# Altium schematic sheet 00_main_with_mcu.SchDoc(00_main_with_mcu)
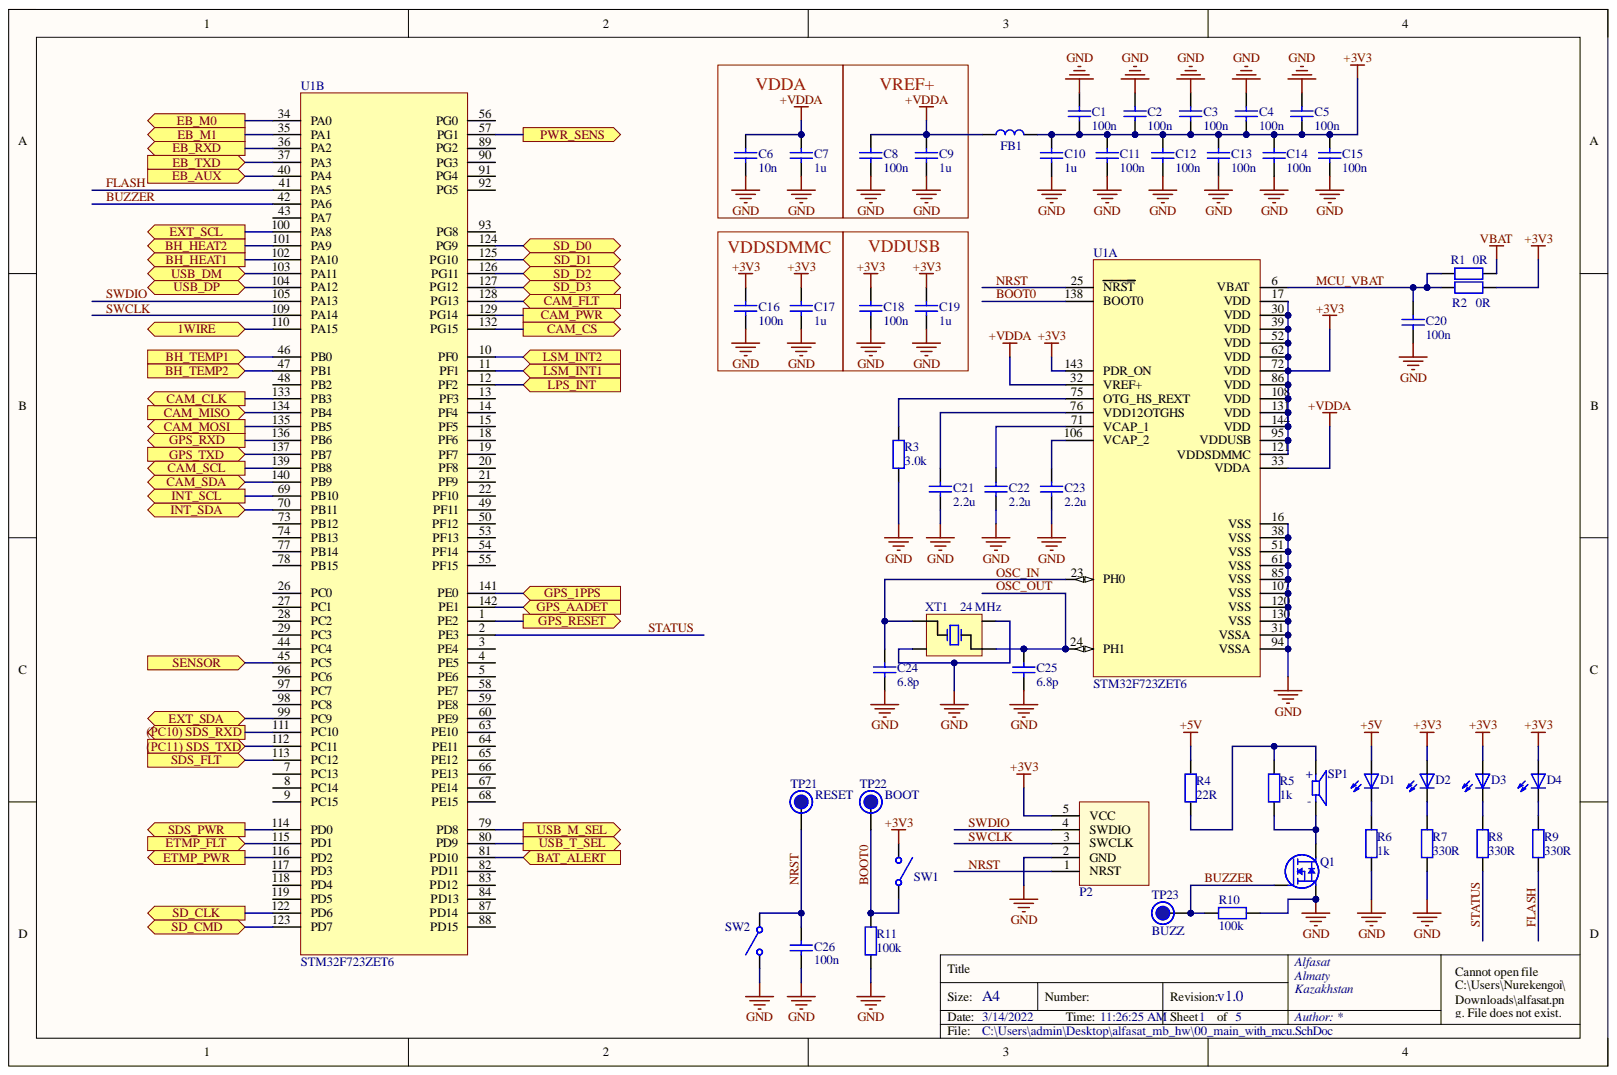
[diagram p0: 00_main_with_mcu.SchDoc]

## Parts
C1  100n  [C0603_IPC_MD]
C2  100n  [C0603_IPC_MD]
C3  100n  [C0603_IPC_MD]
C4  100n  [C0603_IPC_MD]
C5  100n  [C0603_IPC_MD]
C6  10n  [C0603_IPC_MD]
C7  1u  [C0603_IPC_MD]
C8  100n  [C0603_IPC_MD]
C9  1u  [C0603_IPC_MD]
C10  1u  [C0603_IPC_MD]
C11  100n  [C0603_IPC_MD]
C12  100n  [C0603_IPC_MD]
C13  100n  [C0603_IPC_MD]
C14  100n  [C0603_IPC_MD]
C15  100n  [C0603_IPC_HD]
C16  100n  [C0603_IPC_MD]
C17  1u  [C0603_IPC_MD]
C18  100n  [C0603_IPC_HD]
C19  1u  [C0603_IPC_HD]
C20  100n  [C0603_IPC_MD]
C21  2.2u  [C0603_IPC_MD]
C22  2.2u  [C0603_IPC_MD]
C23  2.2u  [C0603_IPC_MD]
C24  6.8p  [C0603_IPC_MD]
C25  6.8p  [C0603_IPC_MD]
C26  100n  [C0603_IPC_MD]
D1  LTST-C190GKT  [LED_0603]  (value not drawn)
D2  LTST-C190GKT  [LED_0603]  (value not drawn)
D3  LTST-C190GKT  [LED_0603]  (value not drawn)
D4  LTST-C190GKT  [LED_0603]  (value not drawn)
FB1  MH1608-601Y  [L0603_IPC_MD]  (value not drawn)
P2  SWD  [SWD_CON_VAR2]  (no BOM)
Q1  BSS816NW  [SOT323]  (value not drawn)
R1  0R  [R0603_IPC_MD]
R2  0R  [R0603_IPC_MD]
R3  3.0k  [R0603_IPC_MD]
R4  22R  [R0603_IPC_MD]
R5  1k  [R0603_IPC_MD]
R6  1k  [R0603_IPC_MD]
R7  330R  [R0603_IPC_MD]
R8  330R  [R0603_IPC_MD]
R9  330R  [R0603_IPC_MD]
R10  100k  [R0603_IPC_MD]
R11  100k  [R0603_IPC_MD]
SP1  MLT-7525  [SPKR_MLT-7525]  (value not drawn)
SW1  TSA008A18026B  [Switch_tactile_3mm*3.5mm]  (value not drawn)
SW2  TSA008A18026B  [Switch_tactile_3mm*3.5mm]  (value not drawn)
TP21  RESET  [TestPoint_1M0]  (no BOM)
TP22  BOOT  [TestPoint_1M0]  (no BOM)
TP23  BUZZ  [TestPoint_1M0]  (no BOM)
U1  STM32F723ZET6  [QFP50P2200X2200X160-144N]  (parts A,B)
XT1  value 24 MHz  [3.2x2.5_4pad_smd]  (hidden comment: TSX-3225-24.0000MF15X-AC6)

## Ports
1WIRE, BAT_ALERT, BH_HEAT1, BH_HEAT2, BH_TEMP1, BH_TEMP2, CAM_CLK, CAM_CS, CAM_FLT, CAM_MISO, CAM_MOSI, CAM_PWR, CAM_SCL, CAM_SDA, EB_AUX, EB_M0, EB_M1, EB_RXD, EB_TXD, ETMP_FLT, ETMP_PWR, EXT_SCL, EXT_SDA, GPS_1PPS, GPS_AADET, GPS_RESET, GPS_RXD, GPS_TXD, INT_SCL, INT_SDA, LPS_INT, LSM_INT1, LSM_INT2, PWR_SENS, SD_CLK, SD_CMD, SD_D0, SD_D1, SD_D2, SD_D3, SDS_FLT, SDS_PWR, SDS_RXD, SDS_TXD, SENSOR, USB_DM, USB_DP, USB_M_SEL, USB_T_SEL

## Nets
+3V3: C1.1 C2.1 C3.1 C4.1 C5.1 C10.2 C11.1 C12.1 C13.1 C14.2 C15.1 C16.1 C17.2 C18.1 C19.2 D2.2 D3.2 D4.2 FB1.2 P2.5 R2.1 SW1.2 U1.17 U1.30 U1.39 U1.52 U1.62 U1.72 U1.86 U1.95 U1.108 U1.121 U1.131 U1.143 U1.144
+5V: D1.2 R4.2
+VDDA: C6.2 C7.2 C8.1 C9.2 FB1.1 U1.32 U1.33
GND: C1.2 C2.2 C3.2 C4.2 C5.2 C6.1 C7.1 C8.2 C9.1 C10.1 C11.2 C12.2 C13.2 C14.1 C15.2 C16.2 C17.1 C18.2 C19.1 C20.1 C21.1 C22.1 C23.1 C24.1 C25.1 C26.2 P2.2 Q1.2 R3.1 R6.1 R7.1 R10.2 R11.1 SW2.2 U1.16 U1.31 U1.38 U1.51 U1.61 U1.85 U1.94 U1.107 U1.120 U1.130 XT1.2 XT1.4
VBAT: R1.1
1WIRE: U1.110 ; port 1WIRE
BAT_ALERT: U1.81 ; port BAT_ALERT
BH_HEAT1: U1.102 ; port BH_HEAT1
BH_HEAT2: U1.101 ; port BH_HEAT2
BH_TEMP1: U1.46 ; port BH_TEMP1
BH_TEMP2: U1.47 ; port BH_TEMP2
BOOT0: R11.2 SW1.1 TP22.0 U1.138
BUZZER: Q1.1 R10.1 TP23.0 U1.42
CAM_CLK: U1.133 ; port CAM_CLK
CAM_CS: U1.132 ; port CAM_CS
CAM_FLT: U1.128 ; port CAM_FLT
CAM_MISO: U1.134 ; port CAM_MISO
CAM_MOSI: U1.135 ; port CAM_MOSI
CAM_PWR: U1.129 ; port CAM_PWR
CAM_SCL: U1.139 ; port CAM_SCL
CAM_SDA: U1.140 ; port CAM_SDA
EB_AUX: U1.40 ; port EB_AUX
EB_M0: U1.34 ; port EB_M0
EB_M1: U1.35 ; port EB_M1
EB_RXD: U1.36 ; port EB_RXD
EB_TXD: U1.37 ; port EB_TXD
ETMP_FLT: U1.115 ; port ETMP_FLT
ETMP_PWR: U1.116 ; port ETMP_PWR
EXT_SCL: U1.100 ; port EXT_SCL
EXT_SDA: U1.99 ; port EXT_SDA
FLASH: R9.1 U1.41
GPS_1PPS: U1.141 ; port GPS_1PPS
GPS_AADET: U1.142 ; port GPS_AADET
GPS_RESET: U1.1 ; port GPS_RESET
GPS_RXD: U1.136 ; port GPS_RXD
GPS_TXD: U1.137 ; port GPS_TXD
INT_SCL: U1.69 ; port INT_SCL
INT_SDA: U1.70 ; port INT_SDA
LPS_INT: U1.12 ; port LPS_INT
LSM_INT1: U1.11 ; port LSM_INT1
LSM_INT2: U1.10 ; port LSM_INT2
MCU_VBAT: C20.2 R1.2 R2.2 U1.6
NRST: C26.1 P2.1 SW2.1 TP21.0 U1.25
OSC_IN: C24.2 U1.23 XT1.1
OSC_OUT: C25.2 U1.24 XT1.3
PWR_SENS: U1.57 ; port PWR_SENS
SD_CLK: U1.122 ; port SD_CLK
SD_CMD: U1.123 ; port SD_CMD
SD_D0: U1.124 ; port SD_D0
SD_D1: U1.125 ; port SD_D1
SD_D2: U1.126 ; port SD_D2
SD_D3: U1.127 ; port SD_D3
SDS_FLT: U1.113 ; port SDS_FLT
SDS_PWR: U1.114 ; port SDS_PWR
SDS_RXD: U1.111 ; port SDS_RXD
SDS_TXD: U1.112 ; port SDS_TXD
SENSOR: U1.45 ; port SENSOR
STATUS: R8.1 U1.2
SWCLK: P2.3 U1.109
SWDIO: P2.4 U1.105
USB_DM: U1.103 ; port USB_DM
USB_DP: U1.104 ; port USB_DP
USB_M_SEL: U1.79 ; port USB_M_SEL
USB_T_SEL: U1.80 ; port USB_T_SEL
NetC21_2: C21.2 U1.76
NetC22_2: C22.2 U1.71
NetC23_2: C23.2 U1.106
NetD1_1: D1.1 R6.2
NetD2_1: D2.1 R7.2
NetD3_1: D3.1 R8.2
NetD4_1: D4.1 R9.2
NetQ1_3: Q1.3 R5.1 SP1.N
NetR3_2: R3.2 U1.75
NetR4_1: R4.1 R5.2 SP1.P

Not connected: U1 3 4 5 7 8 9 13 14 15 18 19 20 21 22 26 27 28 29 43 44 48 49 50 53 54 55 56 58 59 60 63 64 65 66 67 68 73 74 77 78 82 83 84 87 88 89 90 91 92 93 96 97 98 117 118 119

## Library data of the parts
C1-C5 C8 C11-C16 C18 C20 C26 = CL10B104KO8NNNC: CAP CER 0.1UF 16V X7R 0603; Manufacturer: Samsung Electro-Mechanics; Component Kind: Standard; Dielectric: X7R; Manufacturer Part Number: CL10B104KO8NNNC; Supplier 1: Digi-Key; Supplier Part Number 1: 1276-1005-1-ND; Value: 100n; Voltage: 16V; Library Name: alfasat_parts_lib.IntLib
C6 = CL10B103KB8NNNC: CAP CER 0.01UF 50V X7R 0603; Manufacturer: Samsung Electro-Mechanics; Component Kind: Standard; Dielectric: X7R; Manufacturer Part Number: CL10B103KB8NNNC; Supplier 1: Digi-Key; Supplier Part Number 1: 1276-1009-1-ND; Value: 10n; Voltage: 50V; Library Name: alfasat_parts_lib.IntLib
C7 C9 C10 C17 C19 = CL10B105MO8NNWC: CAP CER 1UF 16V X7R 0603; Manufacturer: Samsung Electro-Mechanics; Component Kind: Standard; Dielectric: X7R; Manufacturer Part Number: CL10B105MO8NNWC; Supplier 1: Digi-Key; Supplier Part Number 1: 1276-6524-1-ND; Value: 1u; Voltage: 16V; Library Name: alfasat_parts_lib.IntLib
C21-C23 = GRM188Z71E225KE43D: CAP CER 2.2UF 25V X7R 0603; Manufacturer: Murata Electronics; Component Kind: Standard; Dielectric: X7R; Manufacturer Part Number: GRM188Z71E225KE43D; Supplier 1: LCSC; Supplier Part Number 1: C415535; Value: 2.2u; Voltage: 25V; Library Name: alfasat_parts_lib.IntLib
C24 C25 = CL10C6R8CB8NNNC: CAP CER 6.8PF 50V C0G/NP0 ±0.25pF 0603; Manufacturer: Samsung Electro-Mechanics; Component Kind: Standard; Dielectric: C0G/NP0; Manufacturer Part Number: CL10C6R8CB8NNNC; Supplier 1: LCSC; Supplier Part Number 1: C318672; Value: 6.8p; Voltage: 50V; Library Name: alfasat_parts_lib.IntLib
D1-D4 = LTST-C190GKT: LED GREEN CLEAR CHIP SMD 0603; Manufacturer: Lite-On Inc.; Color: Green; Component Kind: Standard; Manufacturer Part Number: LTST-C190GKT; Supplier 1: Digi-Key; Supplier Part Number 1: 160-1183-1-ND; Library Name: alfasat_parts_lib.IntLib
FB1 = MH1608-601Y: FERRITE BEAD 600R@100MHz 0603 for Power Line, 1000mA; Manufacturer: Bourns Inc.; Component Kind: Standard; Manufacturer Part Number: MH1608-601Y; Supplier 1: Digi-Key; Supplier Part Number 1: MH1608-601YCT-ND; Library Name: alfasat_parts_lib.IntLib
P2 = SWD-CONNECTOR_VAR1: SWDIO SWCLK VDD GND NRST; Library Name: alfasat_parts_lib.IntLib
Q1 = BSS816: N-Channel Logic Level Enhancement Mode Field Effect Transistor; Manufacturer: Infineon Technologies; Component Kind: Standard; Manufacturer Part Number: BSS816NW; Supplier 1: Digi-Key; Supplier Part Number 1: BSS816NWH6327XTSA1CT-ND; Library Name: alfasat_parts_lib.IntLib
R1 R2 = CR0603-J/-000ELF: RES SMD 0 OHM JUMPER 1/10W 0603; Manufacturer: Bourns Inc.; Tolarance: 1%; Component Kind: Standard; Manufacturer Part Number: CR0603-J/-000ELF; Supplier 1: Digi-Key; Supplier Part Number 1: CR0603-J/-000ELFCT-ND; Value: 0R; Library Name: alfasat_parts_lib.IntLib
R3 = RC0603DR-073KL: RES SMD 3.0K OHM 0.5% 1/10W 0603; Manufacturer: Yageo; Component Kind: Standard; Manufacturer Part Number: RC0603DR-073KL; Supplier 1: LCSC; Supplier Part Number 1: C471820; Tolarance: 0.5%; Value: 3.0k; Library Name: alfasat_parts_lib.IntLib
R4 = CR0603-JW-220ELF: RES SMD 22 OHM 5% 1/10W 0603; Manufacturer: Bourns Inc.; Component Kind: Standard; Manufacturer Part Number: CR0603-JW-220ELF; Supplier 1: Digi-Key; Supplier Part Number 1: CR0603-JW-220ELFCT-ND; Tolarance: 5%; Value: 22R; Library Name: alfasat_parts_lib.IntLib
R5 R6 = RMCF0603FT1K00: RES 1K OHM 1% 1/10W 0603; Manufacturer: Stackpole Electronics Inc; Component Kind: Standard; Manufacturer Part Number: RMCF0603FT1K00; Supplier 1: Digi-Key; Supplier Part Number 1: RMCF0603FT1K00CT-ND; Tolarance: 1%; Value: 1k; Library Name: alfasat_parts_lib.IntLib
R7-R9 = CR0603-JW-331ELF: RES SMD 330 OHM 5% 1/10W 0603; Manufacturer: Bourns Inc.; Component Kind: Standard; Manufacturer Part Number: CR0603-JW-331ELF; Supplier 1: Digi-Key; Supplier Part Number 1: CR0603-JW-331ELFCT-ND; Tolarance: 5%; Value: 330R; Library Name: alfasat_parts_lib.IntLib
R10 R11 = RMCF0603FT100K: RES 100K OHM 1% 1/10W 0603; Manufacturer: Bourns Inc.; Component Kind: Standard; Manufacturer Part Number: RMCF0603FT100K; Supplier 1: Digi-Key; Supplier Part Number 1: RMCF0603FT100KCT-ND; Tolarance: 1%; Value: 100k; Library Name: alfasat_parts_lib.IntLib
SP1 = MLT-7525: BUZZER PIEZO 1.5V 12X12MM SMD; Manufacturer: Jiangsu Huaneng Elec; Component Kind: Standard; Manufacturer Part Number: MLT-7525; Supplier 1: LCSC; Supplier Part Number 1: C95299; Library Name: alfasat_parts_lib.IntLib
SW1 SW2 = SW-DPST TSA008A18026B: 3mm 1.8mm 12V 50mA Round Button Brick nogging 3.5mm 12V SMD Tactile Switches ROHS; Manufacturer: BZCN; Component Kind: Standard; Manufacturer Part Number: TSA008A18026B; Supplier 1: LCSC; Supplier Part Number 1: C2888426; Library Name: alfasat_parts_lib.IntLib
TP21-TP23 = TP: Test Point; Library Name: alfasat_parts_lib.IntLib
U1 = STM32F723ZET6: ARM® Cortex®-M7 series Microcontroller IC 32-Bit 216MHz 512KB FLASH 144-LQFP (20x20); Manufacturer: STMicroelectronics; SRAM: 256KB; Component Kind: Standard; FLASH: 512KB; Manufacturer Part Number: STM32F723ZET6; Supplier 1: LCSC; Supplier Part Number 1: C1340305; Library Name: alfasat_parts_lib.IntLib
XT1 = TSX-3225-24.0000MF15X-AC61: CRYSTAL 24.00 MHZ 9.0PF SMD; Manufacturer: EPSON; Component Kind: Standard; Manufacturer Part Number: TSX-3225-24.0000MF15X-AC6; Supplier 1: Digi-Key; Supplier Part Number 1: SER4180CT-ND; Library Name: alfasat_parts_lib.IntLib
